# Altium schematic sheet eBox_relay.SchDoc
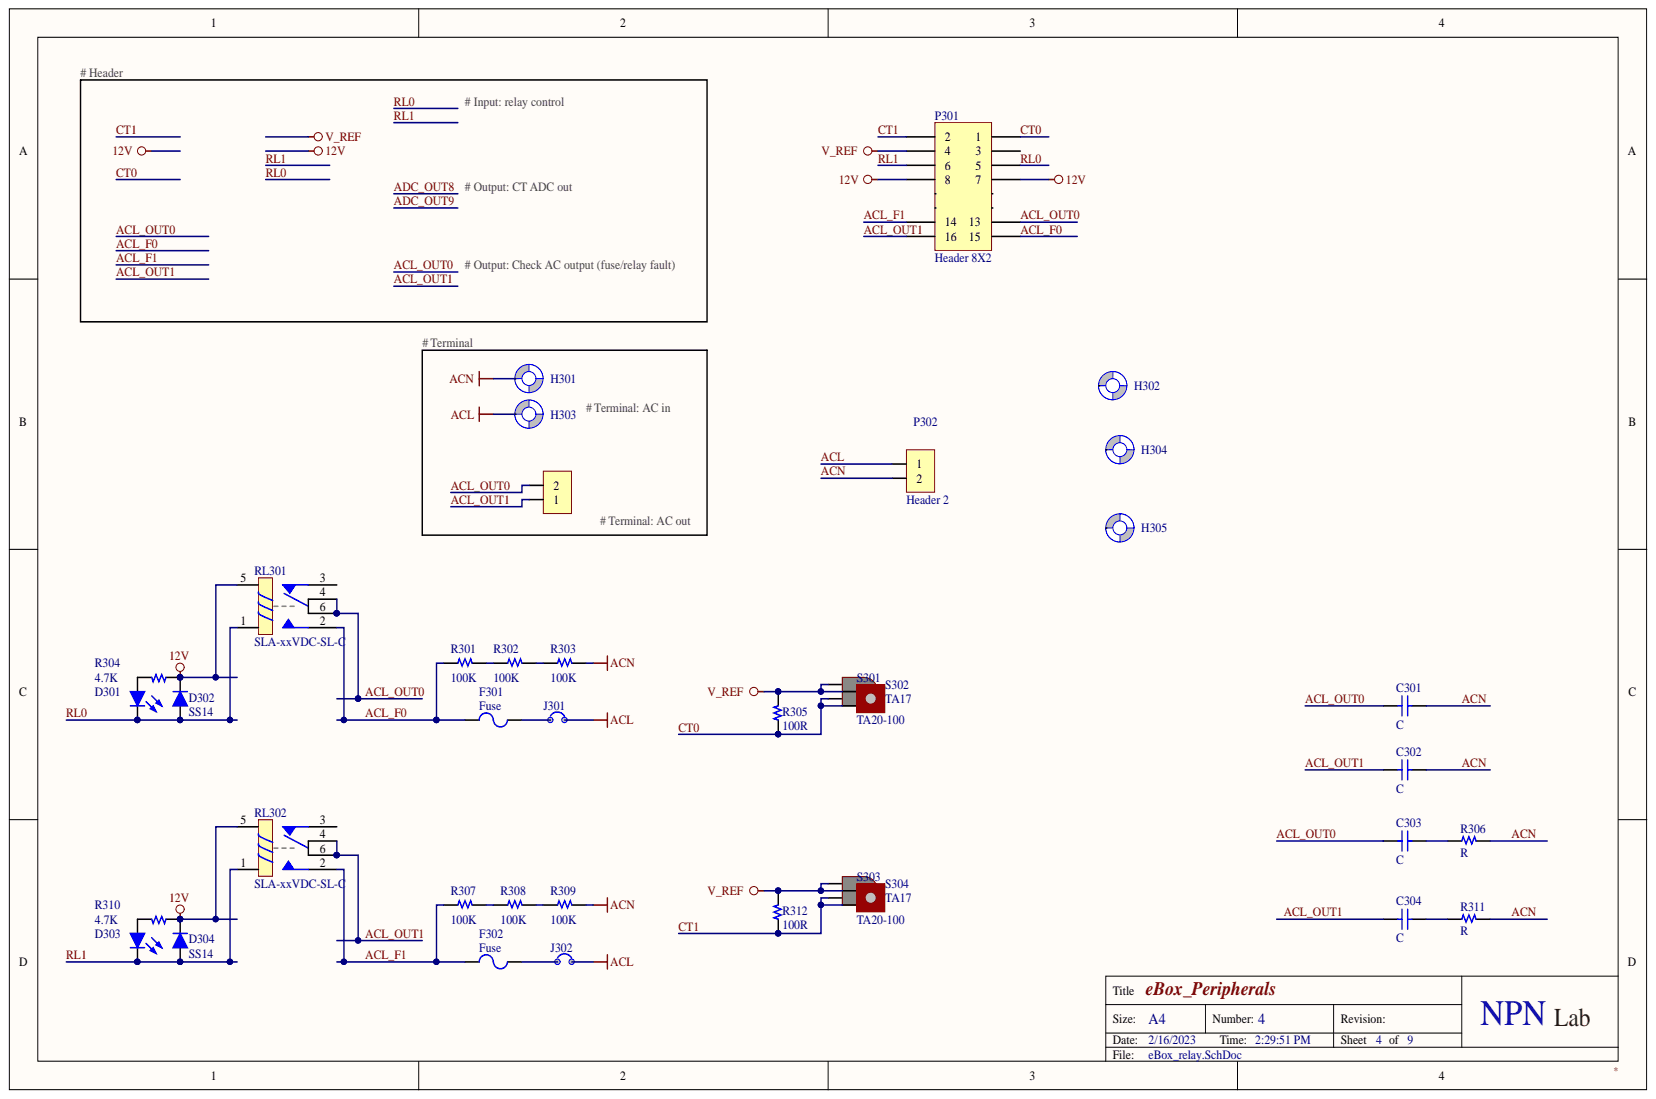
[diagram p0: eBox_relay.SchDoc]

## Parts
C301  C  [CAP Ceramic/W300]
C302  C  [CAP Ceramic/W300]
C303  C  [CAP Ceramic/W550]
C304  C  [CAP Ceramic/W550]
D301  Led  [LED 3MM - green]  (value not drawn)
D302  SS14  [DO-214AC (SMA) L]
D303  Led  [LED 3MM - green]  (value not drawn)
D304  SS14  [DO-214AC (SMA) L]
F301  Fuse  [FUSE 4 DIP 0630]
F302  Fuse  [FUSE 4 DIP 0630]
H301  Screw Hole  [SCREW/3.2/7.0/M]  (value not drawn)  (no BOM)
H302  Screw Hole  [SCREW/3.2/7.0/M]  (value not drawn)  (no BOM)
H303  Screw Hole  [SCREW/3.2/7.0/M]  (value not drawn)  (no BOM)
H304  Screw Hole  [SCREW/3.2/7.0/M]  (value not drawn)  (no BOM)
H305  Screw Hole  [SCREW/3.2/7.0/M]  (value not drawn)  (no BOM)
J301  JUMP 2 CUSTOM  [JUMP/2/Custom]  (value not drawn)  (no BOM)
J302  JUMP 2 CUSTOM  [JUMP/2/Custom]  (value not drawn)  (no BOM)
P301  Header 8X2  [HDR2X8]
P302  Header 2  [HDR/Terminal/2/KF1000]  (no BOM)
P305  Header 2  [HDR/Terminal/2/KF1000]  (value not drawn)  (no BOM)
R301  100K  [R 1206]
R302  100K  [R 1206]
R303  100K  [R 1206]
R304  4.7K  [R 0603]
R305  100R  [R 0805]
R306  R  [R 1W]
R307  100K  [R 1206]
R308  100K  [R 1206]
R309  100K  [R 1206]
R310  4.7K  [R 0603]
R311  R  [R 1W]
R312  100R  [R 0805]
RL301  SLA-xxVDC-SL-C  [RL_SPDT_30A]
RL302  SLA-xxVDC-SL-C  [RL_SPDT_30A]
S301  TA20-100  [TA20-100]
S302  TA17  [TA17]
S303  TA20-100  [TA20-100]
S304  TA17  [TA17]

## Nets
12V: D302.2 D304.2 P301.7 P301.8 R304.1 R310.1 RL301.5 RL302.5
V_REF: P301.4 R305.2 R312.2 S301.3 S302.1 S303.3 S304.1
ACL: H303.1 J301.2 J302.2 P302.1
ACL_F0: F301.1 P301.15 R301.1 RL301.2
ACL_F1: F302.1 P301.14 R307.1 RL302.2
ACL_OUT0: C301.1 C303.1 P301.13 P305.2 RL301.4 RL301.6
ACL_OUT1: C302.1 C304.1 P301.16 P305.1 RL302.4 RL302.6
ACN: C301.2 C302.2 H301.1 P302.2 R303.2 R306.2 R309.2 R311.2
CT0: P301.1 R305.1 S301.4 S302.2
CT1: P301.2 R312.1 S303.4 S304.2
RL0: D301.2 D302.1 P301.5 RL301.1
RL1: D303.2 D304.1 P301.6 RL302.1
NetC303_2: C303.2 R306.1
NetC304_2: C304.2 R311.1
NetD301_1: D301.1 R304.2
NetD303_1: D303.1 R310.2
NetF301_2: F301.2 J301.1
NetF302_2: F302.2 J302.1
NetR301_2: R301.2 R302.1
NetR302_2: R302.2 R303.1
NetR307_2: R307.2 R308.1
NetR308_2: R308.2 R309.1
ADC_OUT8:
ADC_OUT9:

Not connected: H302 1; H304 1; H305 1; P301 3 9 10 11 12; RL301 3; RL302 3

## Library data of the parts
C301-C304 = Capacitor NP: Capacitor non-pol; Component Kind: Standard; Library Name: common_altium_libs.IntLib
D301 D303 = Led: LED GREEN DIFFUSED 3MM ROUND T/H; TYPE: SMD; Component Kind: Standard; Library Name: chipfc_altium_libs.IntLib; PACKAGE: SMD0805; STOCK CODE: HT17-2102SURC; STOCK DESC: SMD-0805 High Bright Red Chip LED; SUPPLIER: tme.vn; UNIT PRICE: 800
D302 D304 = Diode: DIODE SCHOTTKY 40V 1A SMA; BRAND: Toshiba; TYPE: SMD; Component Kind: Standard; Library Name: chipfc_altium_libs.IntLib; PACKAGE: DO-214AC; PART NO: SS14; STOCK CODE: SS14; STOCK DESC: 1A 40V Schottky Barrier Diode; SUPPLIER: tme.vn; UNIT PRICE: 1000
P301 = Header 8X2: Header, 8-Pin, Dual row; Component Kind: Standard; Library Name: chipfc_altium_libs.IntLib
P302 P305 = Header 2: Header, 2-Pin; Library Name: chipfc_altium_libs.IntLib
R301-R303 R307-R309 = Resistor: RES SMD 10K OHM 5% 1/4W 1206; Component Kind: Standard; Library Name: chipfc_altium_libs.IntLib; PACKAGE: SMD1206; STOCK DESC: RES SMD 10K OHM 5% 1/4W 1206; SUPPLIER: banlinhkien.com; TYPE: SMD; UNIT PRICE: 240
R304 R310 = Resistor: RES SMD 4.7K OHM 1% 1/10W 0805; Component Kind: Standard; Library Name: chipfc_altium_libs.IntLib; PACKAGE: SMD0805; STOCK CODE: RM10JTN472; STOCK DESC: 4.7K 5% SMD-0805 Resistor; SUPPLIER: tme.vn; TYPE: SMD; UNIT PRICE: 60
R305 R312 = Resistor: RES SMD 100 OHM 1% 1/10W 0805; Component Kind: Standard; Library Name: chipfc_altium_libs.IntLib; PACKAGE: SMD0805; STOCK CODE: RM10JTN681; STOCK DESC: 680R 5% SMD-0805 Resistor; SUPPLIER: tme.vn; TYPE: SMD; UNIT PRICE: 60
R306 R311 = Resistor: Component Kind: Standard; Description: Resistor; Library Name: common_altium_libs.IntLib
RL301 RL302 = Relay SPDT_SLA: Component Kind: Standard; Description: SLA-xxVDC-SL-C; Library Name: chipfc_altium_libs.IntLib
F301 F302 = Fuse: Component Kind: Standard; Description: Fuse; Library Name: chipfc_altium_libs.IntLib; UNIT PRICE: 0
H301-H305 = Screw Hole: Description: Screw Hole; Library Name: chipfc_altium_libs.IntLib; TYPE: NO-BOM; UNIT PRICE: 0
J301 J302 = JUMP 2 CUSTOM: Library Name: chipfc_altium_libs.IntLib; TYPE: NO-BOM; UNIT PRICE: 0
S301 S303 = TA20-100: Component Kind: Standard; Library Name: chipfc_altium_libs.IntLib
S302 S304 = TA17: Component Kind: Standard; Library Name: chipfc_altium_libs.IntLib
